# Altium schematic sheet Debug_Port.SchDoc
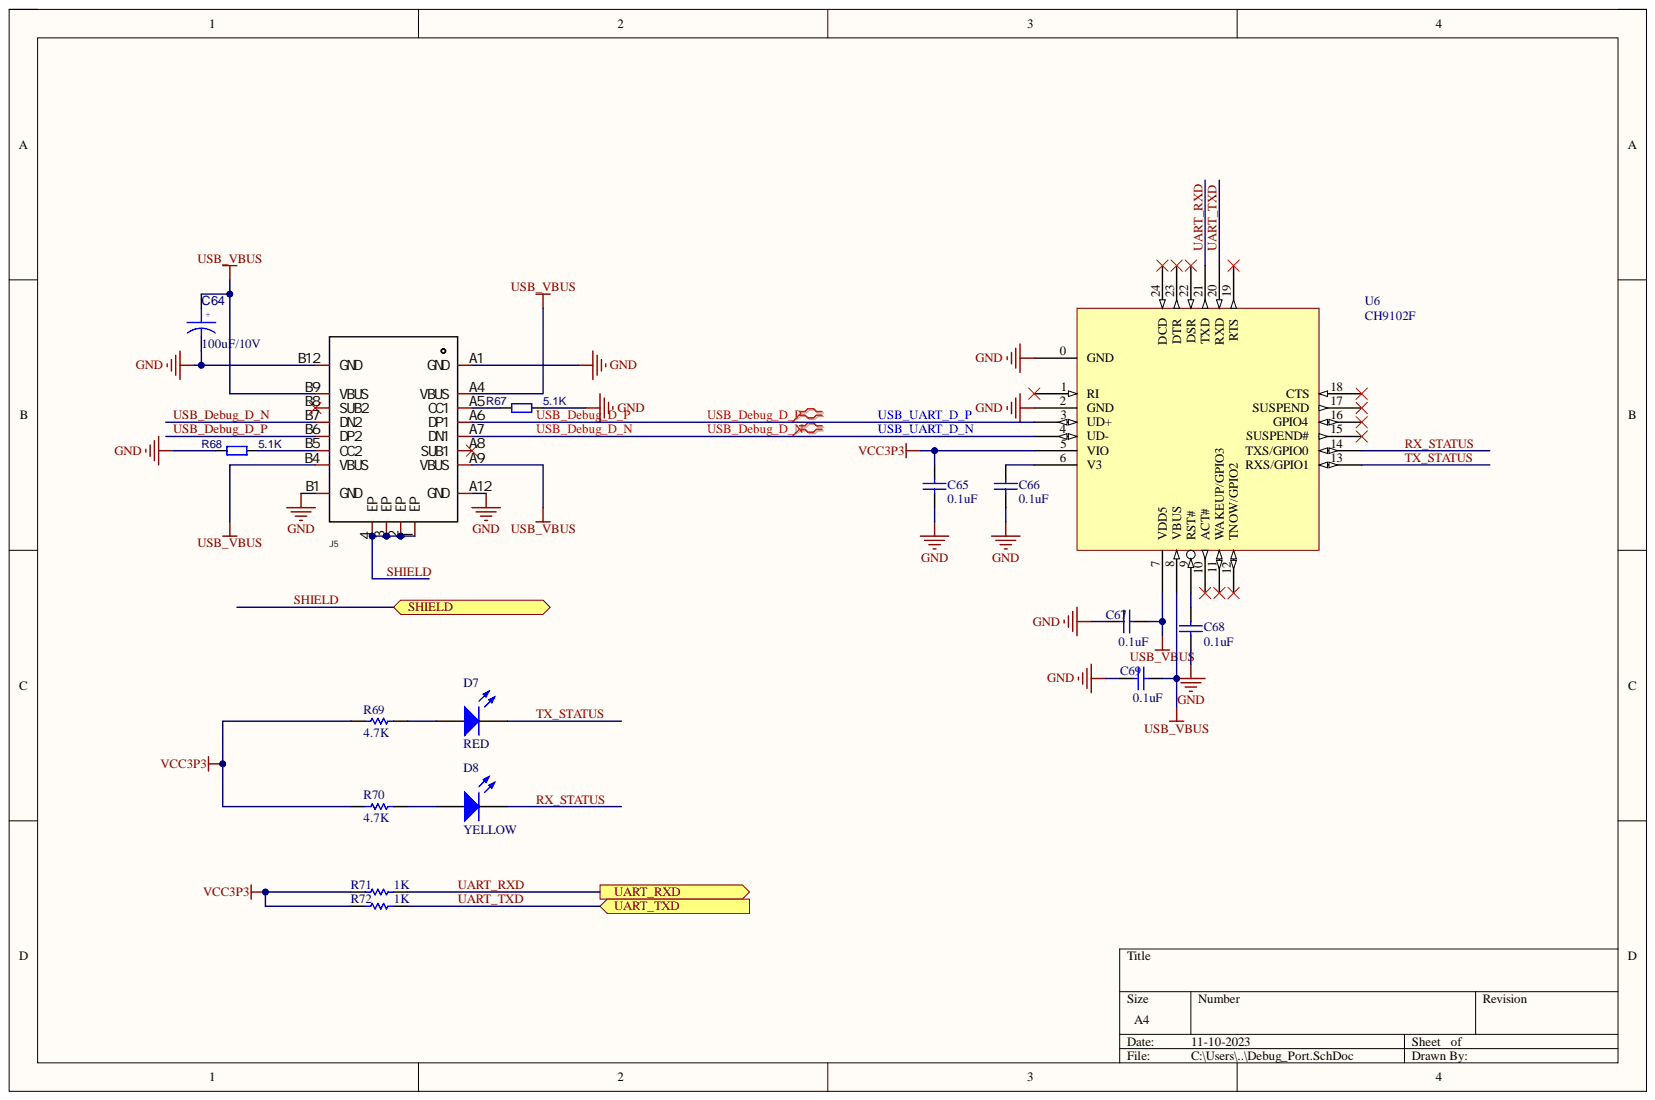
[diagram p0: Debug_Port.SchDoc]

## Parts
C64  100uF/10V  [C1206]
C65  0.1uF  [C0402]
C66  0.1uF  [C0402]
C67  0.1uF  [C0402]
C68  0.1uF  [C0402]
C69  0.1uF  [C0402]
D7  RED  [0603_LED]
D8  YELLOW  [0603_LED]
J5  KH-TYPE-C-16P  [USB_Type-c]  (value not drawn)
R67  5.1K  [R0402]
R68  5.1K  [R0402]
R69  4.7K  [R0402]
R70  4.7K  [R0402]
R71  1K  [R0402]
R72  1K  [R0402]
U6  CH9102F  [qfn24_4x4]

## Ports
SHIELD, UART_RXD, UART_TXD

## Nets
GND: C64.2 C65.2 C66.2 C67.2 C68.1 C69.2 J5.A1(GND) J5.A12(GND) J5.B1(GND) J5.B12(GND) R67.2 R68.1 U6.0(GND) U6.2(GND)
USB_VBUS: C64.1 C67.1 C69.1 J5.A4(VBUS) J5.A9(VBUS) J5.B4(VBUS) J5.B9(VBUS) U6.7(VDD5) U6.8(VBUS)
VCC3P3: C65.1 R69.2 R70.2 R71.2 R72.2 U6.5(VIO)
RX_STATUS: D8.2 U6.14(TXS/GPIO0)
SHIELD: J5.1(EP) J5.2(EP) J5.3(EP) J5.4(EP) ; port SHIELD
TX_STATUS: D7.2 U6.13(RXS/GPIO1)
UART_RXD: R71.1 U6.21(TXD) ; port UART_RXD
UART_TXD: R72.1 U6.20(RXD) ; port UART_TXD
USB_Debug_D_N: J5.A7(DN1) J5.B7(DN2) U6.4(UD-)
USB_Debug_D_P: J5.A6(DP1) J5.B6(DP2) U6.3(UD+)
NetC66_1: C66.1 U6.6(V3)
NetC68_2: C68.2 U6.9(RST#)
NetD7_1: D7.1 R69.1
NetD8_1: D8.1 R70.1
NetJ5_A5: J5.A5(CC1) R67.1
NetJ5_B5: J5.B5(CC2) R68.2

Not connected: J5 A8(SUB1) B8(SUB2); U6 1(RI) 10(ACT#) 11(WAKEUP/GPIO3) 12(TNOW/GPIO2) 15(SUSPEND#) 16(GPIO4) 17(SUSPEND) 18(CTS) 19(RTS) 22(DSR) 23(DTR) 24(DCD)

## Library data of the parts
C64 = EC: Component Kind: Standard; Value: 100uF/10V
C65-C69 = Cap_1: Capacitor; Component Kind: Standard; LatestRevisionDate: 17-Jul-2002; LatestRevisionNote: Re-released for DXP Platform.; Library Name: zynq.SCHLIB; PackageDescription: Radial Cap, Thru-Hole; 2 Leads; 0.3 in Pin Spacing; PackageReference: RAD-0.3; Published: 8-Jun-2000; Signal Integrity: Cap; Simulation: CAP; Value: 0.1uF; Publisher: Altium Limited
D7 D8 = LED2: Typical RED, GREEN, YELLOW, AMBER GaAs LED; Component Kind: Standard; LatestRevisionDate: 17-Jul-2002; LatestRevisionNote: Re-released for DXP Platform.; Library Name: Miscellaneous Devices.IntLib; PackageDescription: SM LED; 2 Flat Leads; PackageReference: 3.2X1.6X1.1; PackageVersion: Feb-2002; Published: 8-Jun-2000; Publisher: Altium Limited
  D7: Value: RED
  D8: Value: YELLOW
J5 = KH-TYPE-C-16P: Manufacturer: kinghelm(金航标); Add into BOM: yes; Connector Type: Type-C; Current Rating - Power (Max): 3A; Gender: 母座; Number of Contacts: 16; Number of Ports: 1; Component Kind: Standard; Convert to PCB: yes; Datasheet: https://atta.szlcsc.com/upload/public/pdf/source/20200911/C709357_AA8DCEB38BB20E3035A7ED7AE7667837.pdf; Device: KH-TYPE-C-16P; JLCPCB Part Class: Extended Part; LCSC Part Name: Type-C 母座 卧贴; Manufacturer Part: KH-TYPE-C-16P; Mounting Style: 卧贴; Name: KH-TYPE-C-16P; Operating Temperature Range: -40℃~+85℃; PCB3D: USB-C-SMD_KH-TYPE-C-16P; Standard: -; Supplier: LCSC; Supplier Footprint: SMD; Supplier Part: C709357; Symbol: KH-TYPE-C-16P; Library Name: Gowin.SchLib
R67 R68 = R: Value: DNI/4K7; Component Kind: Standard; Library Name: zynq.SCHLIB
R69-R72 = Res1: Resistor; Component Kind: Standard; LatestRevisionDate: 17-Jul-2002; LatestRevisionNote: Re-released for DXP Platform.; Library Name: Miscellaneous Devices.IntLib; PackageDescription: Axial Device, Thru-Hole; 2 Leads; 0.3 in Pin Spacing; PackageReference: AXIAL-0.3; Published: 8-Jun-2000; Signal Integrity: Res; Simulation: RESISTOR; Publisher: Altium Limited
  R69 R70: Value: 4.7K
  R71 R72: Value: 1K
U6 = CH9102F: USB to high speed TTL Serial / UART; Component Kind: Standard; Library Name: CHXXX.schlib
